# Altium schematic sheet DCDCConverterUP.SchDoc
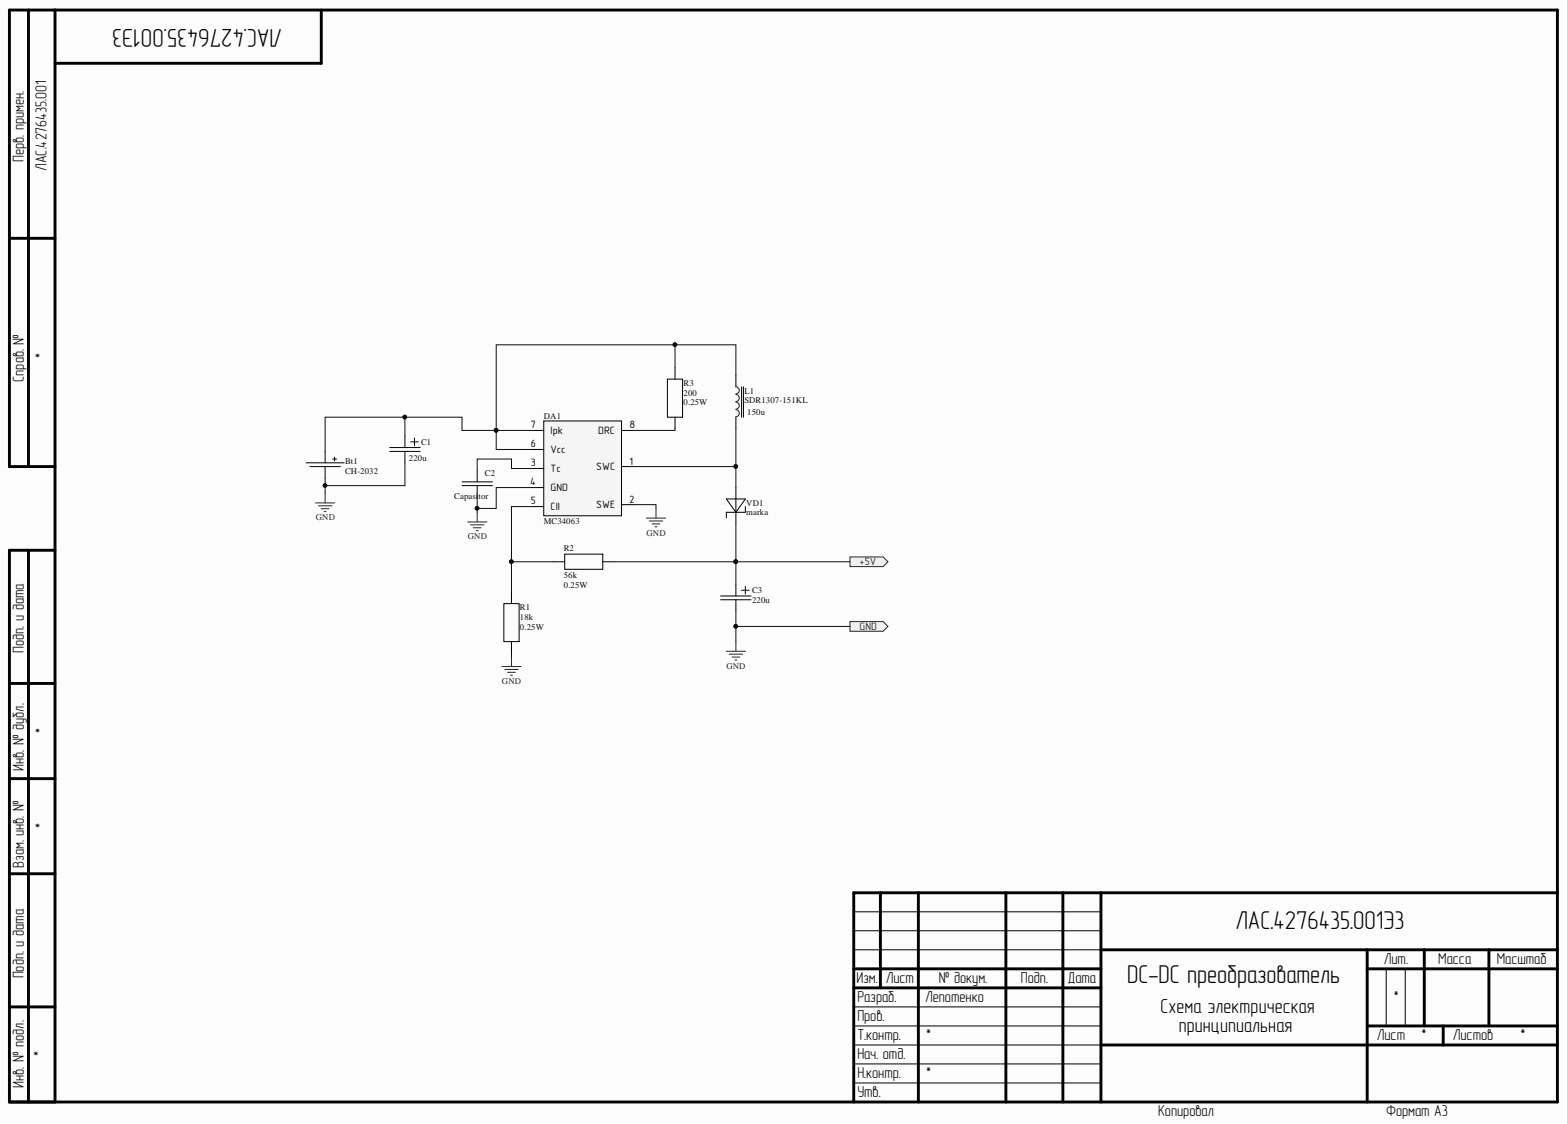
[diagram p0: DCDCConverterUP.SchDoc]

## Parts
Bt1  CH-2032  [CH25-2032]
C1  220u  [Type D]
C2  Capasitor  [CAP1206]
C3  220u  [Type D]
DA1  MC34063  [SO-8]
L1  SDR1307-151KL  value 150u  [SDR1307-151KL]
R1  value 18k  Power: 0.25W  [1206]  (hidden comment: Resistor)
R2  value 56k  Power: 0.25W  [1206]  (hidden comment: Resistor)
R3  value 200  Power: 0.25W  [1206]  (hidden comment: Resistor)
VD1  marka  [SOD-23]

## Ports
+5V, GND

## Nets
+5V: C3.1 R2.1 VD1.2 ; port +5V
GND: Bt1.2 C1.2 C2.2 C3.2 DA1.2(SWE) DA1.4(GND) R1.1 ; port GND
NetBt1_1: Bt1.1 C1.1 DA1.6(Vcc) DA1.7(Ipk) L1.2 R3.2
NetC2_1: C2.1 DA1.3(Tc)
NetDA1_1: DA1.1(SWC) L1.1 VD1.1
NetDA1_5: DA1.5(CII) R1.2 R2.2
NetDA1_8: DA1.8(DRC) R3.1

## Library data of the parts
Bt1 = CR-2032: Manufacturer: Comf; Value: CH25-CR2032; Component Kind: Standard; Package: CH25-2032; Part Number: CH25-2032; Price: 0.36; Quantity: 1; ShoppingPlace: http://belchip.by/product/?selected_product=12241; Library Name: SchlibUGO.SchLib
C1 = Capasitor Electrolit: Manufacturer: Kemet; Part Namber: T520D227M010ATE018; Power: 10V; Component Kind: Standard; Package: TypeD; Price: 0.7; Quantity: 2; ShoppingPlace: http://belchip.by/product/?selected_product=19189; Value: 220u; Library Name: SchlibUGO.SchLib
C2 = Capasitor: Manufacturer: FAITHFULL LINK; Part Number: 1206471X7R50V; Value: 0.47n; Voltage: 50V; Component Kind: Standard; Package: 1206; Price: 0.02; Quantity: 1; ShoppingPlace: http://belchip.by/product/?selected_product=00281; Library Name: SchlibUGO.SchLib
C3 = Capasitor Electrolit: Component Kind: Standard; Library Name: SchlibUGO.SchLib
DA1 = MC34063: Manufacturer: UTC; part Number: MC34063AG; Value: 5V; Component Kind: Standard; Package: SO8; Price: 0.325; Quantity: 1; ShoppingPlace: http://belchip.by/product/?selected_product=18919; Library Name: SchlibUGO.SchLib
L1 = SDR1307-151KL: Manufacturer: BOURNS; Component Kind: Standard; Package: SDR1307; Part Number: SDR1307-151KL; Price: 0.75; Quantity: 1; ShoppingPlace: http://belchip.by/product/?selected_product=06875; Library Name: SchlibUGO.SchLib
R1 = Resistor: Manufacturer: China; Part Number: 1206183; Quntity: 2; Component Kind: Standard; Package: 1206; Price: 0.15; ShoppingPlace: http://belchip.by/product/?selected_product=01413; Library Name: SchlibUGO.SchLib
R2 = Resistor: Manufacturer: china; Part Number: 1206563; Quntity: 2; Component Kind: Standard; Package: 1206; Price: 0.15; ShoppingPlace: http://belchip.by/product/?selected_product=01425; Library Name: SchlibUGO.SchLib
R3 = Resistor: Manufacturer: China; Part Number: SMD12065221; Quntity: 20; Component Kind: Standard; Package: 1206; Price: 1; ShoppingPlace: http://belchip.by/product/?selected_product=01368; Library Name: SchlibUGO.SchLib
VD1 = DiodeShottki: part Number: 1N5819HW; Power: 40V; Value: 1A; Component Kind: Standard; Package: SOD-123; Price: 0.12; Quantity: 1; ShoppingPlace: http://belchip.by/product/?selected_product=08853; Library Name: SchlibUGO.SchLib
